# Altium schematic sheet MCU.SchDoc(MCU)
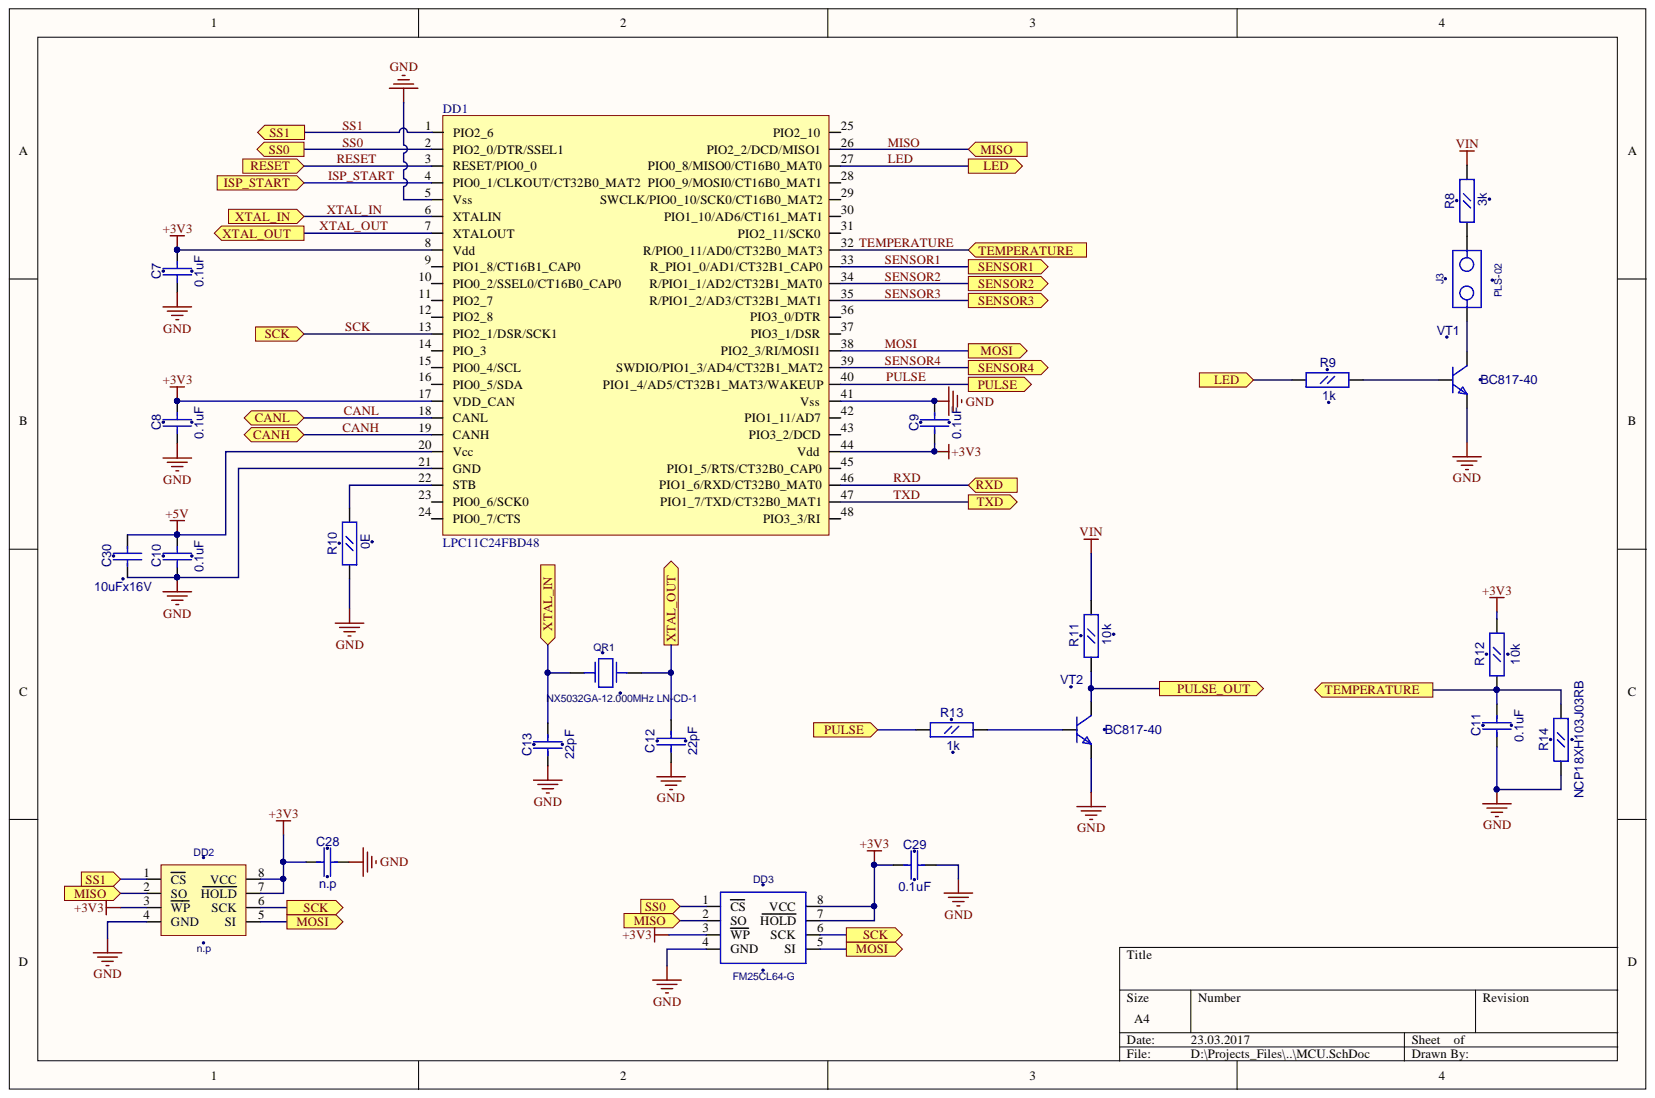
[diagram p0: MCU.SchDoc]

## Parts
C7  0.1uF  [CC0603]
C8  0.1uF  [CC0603]
C9  0.1uF  [CC0603]
C10  0.1uF  [CC0603]
C11  0.1uF  [CC0603]
C12  22pF  [CC0603]
C13  22pF  [CC0603]
C28  n.p  [CC0603]
C29  0.1uF  [CC0603]
C30  10uFx16V  [CC0805]
DD1  LPC11C24FBD48  [LQFP-48]
DD2  n.p  [EIAJ_SO8]
DD3  FM25CL64-G  [SO-8]
J3  PLS-02  [PLS-02]
QR1  NX5032GA-12.000MHz LN-CD-1  [AC5032_2]
R8  3k  [RC0603]
R9  1k  [RC0603]
R10  0E  [RC0603]
R11  10k  [RC0603]
R12  10k  [RC0603]
R13  1k  [RC0603]
R14  NCP18XH103J03RB  [RC0603]
VT1  BC817-40  [SOT-23(123)]
VT2  BC817-40  [SOT-23(123)]

## Ports
CANH, CANL, ISP_START, LED, MISO, MOSI, PULSE, PULSE_OUT, RESET, RXD, SCK, SENSOR1, SENSOR2, SENSOR3, SENSOR4, SS0, SS1, TEMPERATURE, TXD, XTAL_IN, XTAL_OUT

## Nets
+3V3: C7.2 C8.2 C9.1 C28.1 C29.1 DD1.8(Vdd) DD1.17(VDD_CAN) DD1.44(Vdd) DD2.3(WP) DD2.7(HOLD) DD2.8(VCC) DD3.3(WP) DD3.7(HOLD) DD3.8(VCC) R12.2
+5V: C10.2 C30.2 DD1.20(Vcc)
GND: C7.1 C8.1 C9.2 C10.1 C11.1 C12.1 C13.1 C28.2 C29.2 C30.1 DD1.5(Vss) DD1.21(GND) DD1.41(Vss) DD2.4(GND) DD3.4(GND) R10.1 R14.1 VT1.2 VT2.2
VIN: R8.2 R11.2
CANH: DD1.19(CANH) ; port CANH
CANL: DD1.18(CANL) ; port CANL
ISP_START: DD1.4 ; port ISP_START
LED: DD1.27 R9.1 ; port LED
MISO: DD1.26(PIO2_2/DCD/MISO1) DD2.2(SO) DD3.2(SO) ; port MISO
MOSI: DD1.38(PIO2_3/RI/MOSI1) DD2.5(SI) DD3.5(SI) ; port MOSI
PULSE: DD1.40(PIO1_4/AD5/CT32B1_MAT3/WAKEUP) R13.1 ; port PULSE
PULSE_OUT: R11.1 VT2.3 ; port PULSE_OUT
RESET: DD1.3(RESET/PIO0_0) ; port RESET
RXD: DD1.46(PIO1_6/RXD/CT32B0_MAT0) ; port RXD
SCK: DD1.13(PIO2_1/DSR/SCK1) DD2.6(SCK) DD3.6(SCK) ; port SCK
SENSOR1: DD1.33(R_PIO1_0/AD1/CT32B1_CAP0) ; port SENSOR1
SENSOR2: DD1.34(R/PIO1_1/AD2/CT32B1_MAT0) ; port SENSOR2
SENSOR3: DD1.35(R/PIO1_2/AD3/CT32B1_MAT1) ; port SENSOR3
SENSOR4: DD1.39(SWDIO/PIO1_3/AD4/CT32B1_MAT2) ; port SENSOR4
SS0: DD1.2(PIO2_0/DTR/SSEL1) DD3.1(CS) ; port SS0
SS1: DD1.1(PIO2_6) DD2.1(CS) ; port SS1
TEMPERATURE: C11.2 DD1.32(R/PIO0_11/AD0/CT32B0_MAT3) R12.1 R14.2 ; port TEMPERATURE
TXD: DD1.47(PIO1_7/TXD/CT32B0_MAT1) ; port TXD
XTAL_IN: C13.2 DD1.6(XTALIN) QR1.1 ; port XTAL_IN
XTAL_OUT: C12.2 DD1.7(XTALOUT) QR1.2 ; port XTAL_OUT
NetDD1_22: DD1.22(STB) R10.2
NetJ3_1: J3.1 VT1.3
NetJ3_2: J3.2 R8.1
NetR9_2: R9.2 VT1.1
NetR13_2: R13.2 VT2.1

Not connected: DD1 9(PIO1_8/CT16B1_CAP0) 10 11(PIO2_7) 12(PIO2_8) 14(PIO_3) 15 16 23 24 25(PIO2_10) 28 29(SWCLK/PIO0_10/SCK0/CT16B0_MAT2) 30(PIO1_10/AD6/CT161_MAT1) 31(PIO2_11/SCK0) 36(PIO3_0/DTR) 37(PIO3_1/DSR) 42(PIO1_11/AD7) 43(PIO3_2/DCD) 45(PIO1_5/RTS/CT32B0_CAP0) 48(PIO3_3/RI)

## Library data of the parts
C7-C13 C28 C29 = CC0603: Component Kind: Standard; Description: CC0603; Library Name: gprs_modem_2.SchLib
  C7-C11 C29: Value: 0.1uF
  C12 C13: Value: 22pF
  C28: Value: n.p
C30 = CC0805: Component Kind: Standard; Description: CC0805; Value: 10uFx16V; Library Name: gprs_modem_2.SchLib
DD2 = S25FL016A0LMFI01: Component Kind: Standard; Description: S25FL016A0LMFI01; Value: n.p; Library Name: gprs_modem_2.SchLib
QR1 = AC5032_2: AC5032/2; Component Kind: Standard; Value: NX5032GA-12.000MHz LN-CD-1; Library Name: gprs_modem_2.SchLib
R8-R14 = RC0603: Component Kind: Standard; Description: RC0603; Library Name: gprs_modem_2.SchLib
  R8: Value: 3k
  R9 R13: Value: 1k
  R10: Value: 0E
  R11 R12: Value: 10k
  R14: Value: NCP18XH103J03RB
VT1 VT2 = BC846BLT1: NPN 500ma transistor; ComponentField7: Published 29-Sep-2000; Component Kind: Standard; OriginalName: BC846BLT1; Simulation: BC846BLT1; Value: BC817-40; Library Name: gprs_modem_2.SchLib
DD1 = LPC11C24FBD48: Component Kind: Standard; Value: LPC11C24FBD48; Library Name: gprs_modem_2.SchLib
DD3 = FM25CL64-G: Component Kind: Standard; Description: FM25CL64-G; Library Name: gprs_modem_2.SchLib
J3 = PLS-02: Component Kind: Standard; Description: PLS-02; Library Name: gprs_modem_2.SchLib
